# Altium schematic sheet Sheet5.SchDoc
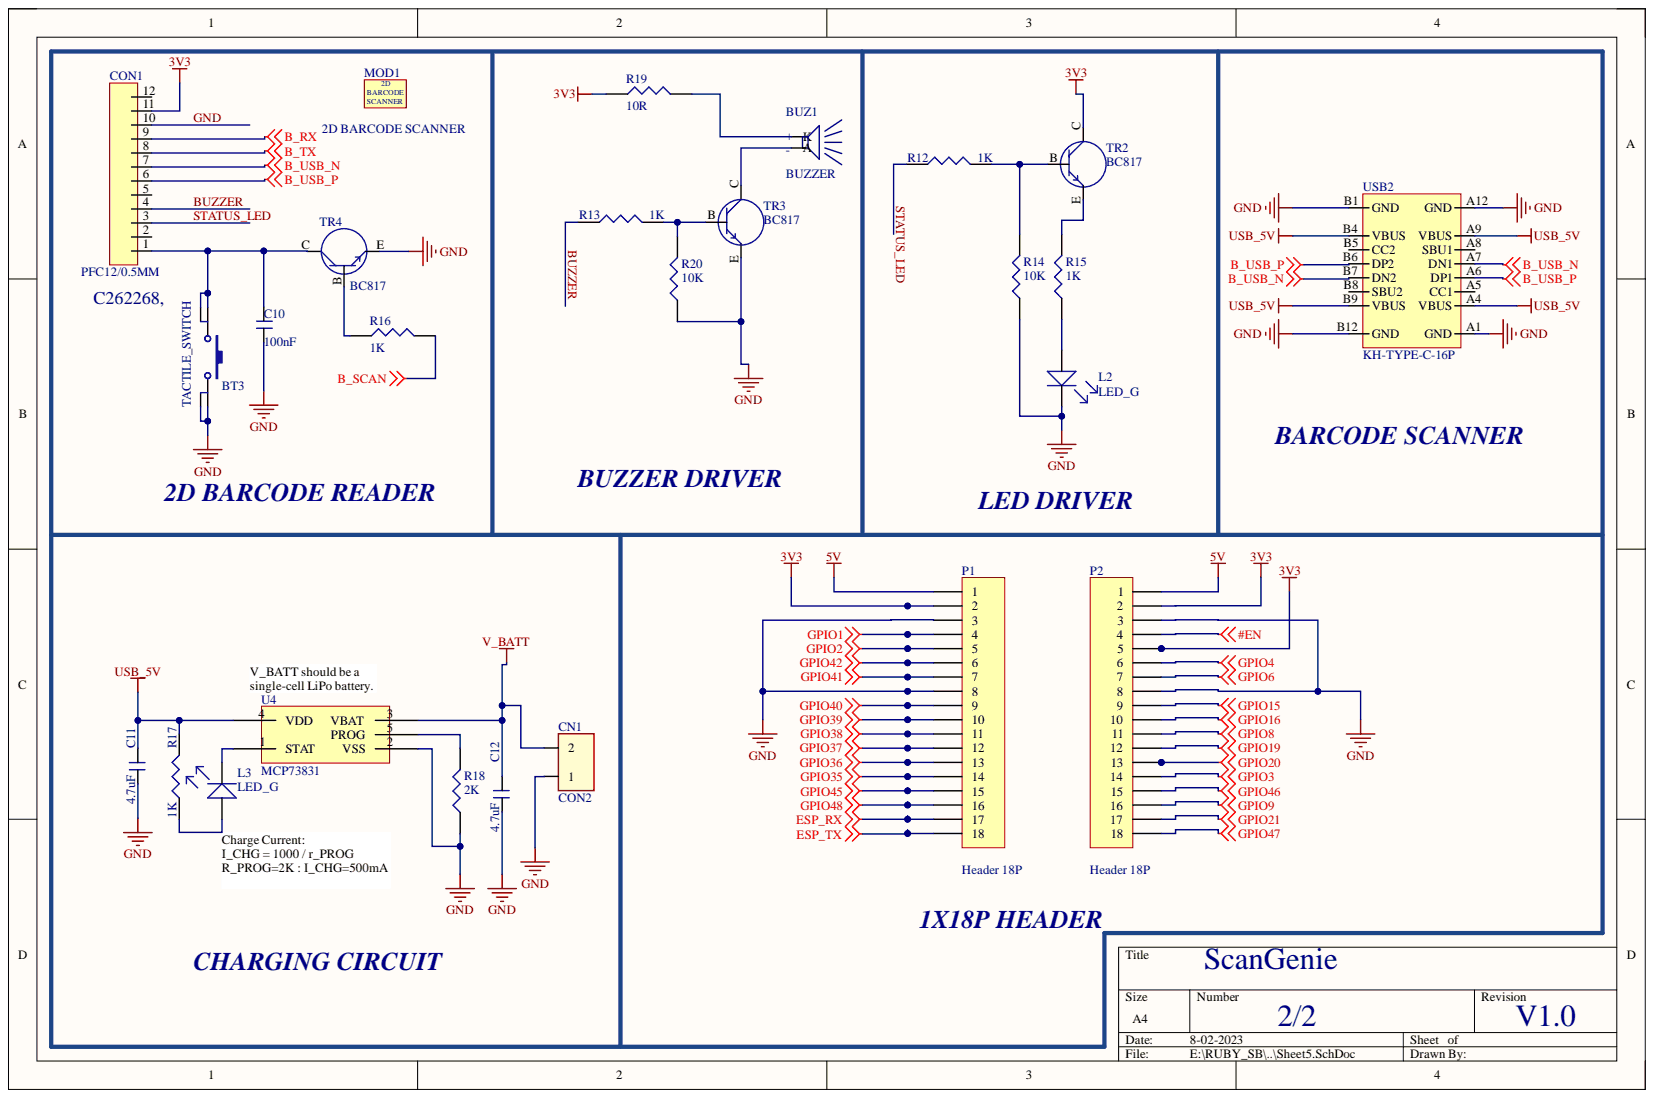
[diagram p0: Sheet5.SchDoc]

## Parts
BT3  TACTILE_SWITCH  [TACTILE]
BUZ1  BUZZER  [SMD BUZZ]
C10  100nF  [C_0603]
C11  4.7uF  [C_0603]
C12  4.7uF  [C_0603]
CN1  CON2  [JST2P_P2.0mm]
CON1  PFC12/0.5MM  [FPC12P_CLAMP-BTM-P0.5]
L2  LED_G  [LED_0603]
L3  LED_G  [LED_0603]
MOD1  2D BARCODE SCANNER  [BARCODE MOD]
P1  Header 18P  [1x18P MAL]
P2  Header 18P  [1x18P MAL]
R12  1K  [R_0603]
R13  1K  [R_0603]
R14  10K  [R_0603]
R15  1K  [R_0603]
R16  1K  [R_0603]
R17  1K  [R_0603]
R18  2K  [R_0603]
R19  10R  [R_0603]
R20  10K  [R_0603]
TR2  BC817  [SOT23]
TR3  BC817  [SOT23]
TR4  BC817  [SOT23]
U4  MCP73831  [SOT23-5]
USB2  KH-TYPE-C-16P  [USBC-31-M-12]

## Nets
3V3: CON1.11 P1.2 P2.2 P2.5 R19.1 TR2.C
5V: P1.1 P2.1
#EN: P2.4
B_RX: CON1.9
B_SCAN: R16.2
B_TX: CON1.8
B_USB_N: CON1.7 USB2.A7(DN1) USB2.B7(DN2)
B_USB_P: CON1.6 USB2.A6(DP1) USB2.B6(DP2)
ESP_RX: P1.17
ESP_TX: P1.18
GPIO1: P1.4
GPIO2: P1.5
GPIO3: P2.14
GPIO4: P2.6
GPIO6: P2.7
GPIO8: P2.11
GPIO9: P2.16
GPIO15: P2.9
GPIO16: P2.10
GPIO19: P2.12
GPIO20: P2.13
GPIO21: P2.17
GPIO35: P1.14
GPIO36: P1.13
GPIO37: P1.12
GPIO38: P1.11
GPIO39: P1.10
GPIO40: P1.9
GPIO41: P1.7
GPIO42: P1.6
GPIO45: P1.15
GPIO46: P2.15
GPIO47: P2.18
GPIO48: P1.16
USB_5V: C11.1 R17.2 U4.4(VDD) USB2.A4(VBUS) USB2.A9(VBUS) USB2.B4(VBUS) USB2.B9(VBUS)
V_BATT: C12.1 CN1.2 U4.3(VBAT)
BUZZER: CON1.4 R13.1
GND: BT3.3 BT3.4 C10.1 C11.2 C12.2 CN1.1 CON1.10 L2.K P1.3 P1.8 P2.3 P2.8 R14.1 R18.1 R20.1 TR3.E TR4.E U4.2(VSS) USB2.A1(GND) USB2.A12(GND) USB2.B1(GND) USB2.B12(GND)
STATUS_LED: CON1.3 R12.1
NetBT3_1: BT3.1 BT3.2 C10.2 CON1.1 TR4.C
NetBUZ1_1: BUZ1.1 R19.2
NetBUZ1_2: BUZ1.2 TR3.C
NetL2_A: L2.A R15.1
NetL3_A: L3.A R17.1
NetL3_K: L3.K U4.1(STAT)
NetR12_2: R12.2 R14.2 TR2.B
NetR13_2: R13.2 R20.2 TR3.B
NetR15_2: R15.2 TR2.E
NetR16_1: R16.1 TR4.B
NetR18_2: R18.2 U4.5(PROG)

Not connected: CON1 2 5 12; USB2 A5(CC1) A8(SBU1) B5(CC2) B8(SBU2)

## Library data of the parts
C10-C12 = CAP_NONPOLAR: Component Kind: Standard; Library Name: dxp_pasl_lib.IntLib
L2 L3 = LED: Component Kind: Standard; Library Name: dxp_pasl_lib.IntLib
P1 P2 = Header 18: Header, 18-Pin; Component Kind: Standard; LatestRevisionDate: 17-Jul-2002; LatestRevisionNote: Re-released for DXP Platform.; Library Name: Miscellaneous Connectors.IntLib; Signal Integrity: Connector; Publisher: Altium Limited
R12-R20 = RES: Component Kind: Standard; Library Name: dxp_pasl_lib.IntLib
TR2-TR4 = TRANSISTER_NPN: Component Kind: Standard; Library Name: dxp_pasl_lib.IntLib
USB2 = USB TYPE-C KH-TYPE-C-16P: 3A 1 Surface Mount 16 Female -40?~+85? Type-C SMD USB Connectors ROHS #  C709357; Component Kind: Standard; ComponentLink2Description: New link; ComponentLink2URL: https://lcsc.com/product-detail/USB-Connectors_Shenzhen-Kinghelm-Elec-KH-TYPE-C-16P_C709357.html; Supplier 1: LCSC; Supplier Part Number 1: C165948; Library Name: dxp_pasl_lib.IntLib
BT3 = TACTILE_SWITCH: Component Kind: Standard; Library Name: dxp_pasl_lib.IntLib
BUZ1 = BUZZER: Component Kind: Standard; Library Name: MIX.SchLib
CN1 = CON2: Component Kind: Standard; Library Name: dxp_pasl_lib.IntLib
CON1 = PFC12/0.5MM: Component Kind: Standard; Library Name: dxp_pasl_lib.IntLib
MOD1 = 2D BARCODE SCANNER: Component Kind: Standard; Library Name: dxp_pasl_lib.IntLib
U4 = MCP73831: Component Kind: Standard; Library Name: dxp_pasl_lib.IntLib
